# Altium schematic sheet MCU.SchDoc(MCU)
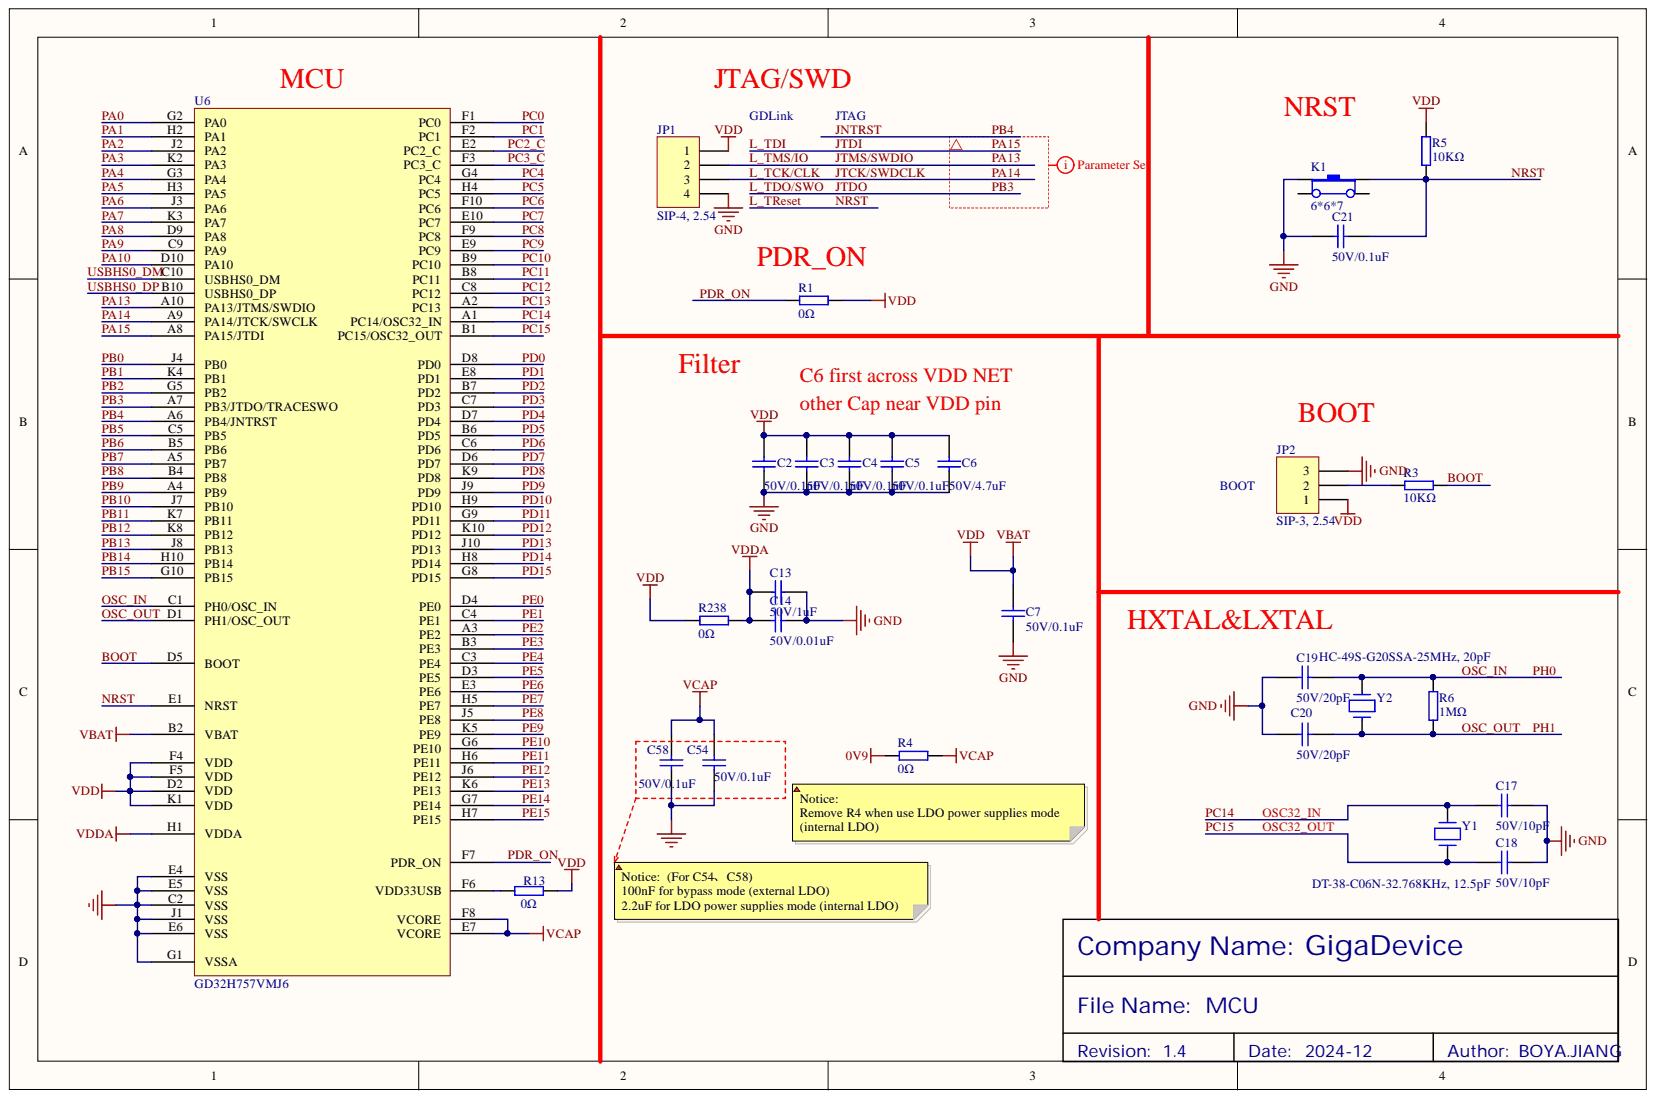
[diagram p0: MCU.SchDoc]

## Parts
C2  50V/0.1uF  [0603-C]
C3  50V/0.1uF  [0603-C]
C4  50V/0.1uF  [0603-C]
C5  50V/0.1uF  [0603-C]
C6  50V/4.7uF  [0603-C]
C7  50V/0.1uF  [0603-C]
C13  50V/1uF  [0603-C]
C14  50V/0.01uF  [0603-C]
C17  50V/10pF  [0603-C]
C18  50V/10pF  [0603-C]
C19  50V/20pF  [0603-C]
C20  50V/20pF  [0603-C]
C21  50V/0.1uF  [0603-C]
C54  50V/0.1uF  [0603-C]
C58  50V/0.1uF  [0603-C]
JP1  SIP-4, 2.54  [SIP-4]
JP2  SIP-3, 2.54  [SIP-3]
K1  6*6*7  [K-1102B]
R1  0Ω  [0603-R]
R3  10KΩ  [0603-R]
R4  0Ω  [0805-R]
R5  10KΩ  [0603-R]
R6  1MΩ  [0603-R]
R13  0Ω  [0603-R]
R238  0Ω  [0603-R]
U6  GD32H757VMJ6  [BGA100_8X8X1.1]
Y1  DT-38-C06N-32.768KHz, 12.5pF  [DT-26]
Y2  HC-49S-G20SSA-25MHz, 20pF  [HC-49S]

## Nets
0V9: R4.1
GND: C2.1 C3.1 C4.1 C5.1 C6.1 C7.2 C13.1 C14.1 C17.2 C18.1 C19.1 C20.1 C21.2 C54.2 C58.2 JP1.4 JP2.3 K1.1 U6.C2(VSS) U6.E4(VSS) U6.E5(VSS) U6.E6(VSS) U6.G1(VSSA) U6.J1(VSS)
VBAT: C2.2 C3.2 C4.2 C5.2 C6.2 C7.1 JP1.1 JP2.1 R1.1 R5.2 R13.1 R238.1 U6.B2(VBAT) U6.D2(VDD) U6.F4(VDD) U6.F5(VDD) U6.K1(VDD)
VCAP: C54.1 C58.1 R4.2 U6.E7(VCORE) U6.F8(VCORE)
VDDA: C13.2 C14.2 R238.2 U6.H1(VDDA)
BOOT: R3.1 U6.D5(BOOT)
JNTRST: U6.A6(PB4/JNTRST)
JTCK/SWDCLK: JP1.3 U6.A9(PA14/JTCK/SWCLK)
JTDI: U6.A8(PA15/JTDI)
JTDO: U6.A7(PB3/JTDO/TRACESWO)
JTMS/SWDIO: JP1.2 U6.A10(PA13/JTMS/SWDIO)
L_TReset: C21.1 K1.3 R5.1 U6.E1(NRST)
OSC_IN: C19.2 R6.2 U6.C1(PH0/OSC_IN) Y2.2
OSC_OUT: C20.2 R6.1 U6.D1(PH1/OSC_OUT) Y2.1
PA0: U6.G2(PA0)
PA1: U6.H2(PA1)
PA2: U6.J2(PA2)
PA3: U6.K2(PA3)
PA4: U6.G3(PA4)
PA5: U6.H3(PA5)
PA6: U6.J3(PA6)
PA7: U6.K3(PA7)
PA8: U6.D9(PA8)
PA9: U6.C9(PA9)
PA10: U6.D10(PA10)
PB0: U6.J4(PB0)
PB1: U6.K4(PB1)
PB2: U6.G5(PB2)
PB5: U6.C5(PB5)
PB6: U6.B5(PB6)
PB7: U6.A5(PB7)
PB8: U6.B4(PB8)
PB9: U6.A4(PB9)
PB10: U6.J7(PB10)
PB11: U6.K7(PB11)
PB12: U6.K8(PB12)
PB13: U6.J8(PB13)
PB14: U6.H10(PB14)
PB15: U6.G10(PB15)
PC0: U6.F1(PC0)
PC1: U6.F2(PC1)
PC2_C: U6.E2(PC2_C)
PC3_C: U6.F3(PC3_C)
PC4: U6.G4(PC4)
PC5: U6.H4(PC5)
PC6: U6.F10(PC6)
PC7: U6.E10(PC7)
PC8: U6.F9(PC8)
PC9: U6.E9(PC9)
PC10: U6.B9(PC10)
PC11: U6.B8(PC11)
PC12: U6.C8(PC12)
PC13: U6.A2(PC13)
PC14: C17.1 U6.A1(PC14/OSC32_IN) Y1.2
PC15: C18.2 U6.B1(PC15/OSC32_OUT) Y1.1
PD0: U6.D8(PD0)
PD1: U6.E8(PD1)
PD2: U6.B7(PD2)
PD3: U6.C7(PD3)
PD4: U6.D7(PD4)
PD5: U6.B6(PD5)
PD6: U6.C6(PD6)
PD7: U6.D6(PD7)
PD8: U6.K9(PD8)
PD9: U6.J9(PD9)
PD10: U6.H9(PD10)
PD11: U6.G9(PD11)
PD12: U6.K10(PD12)
PD13: U6.J10(PD13)
PD14: U6.H8(PD14)
PD15: U6.G8(PD15)
PDR_ON: R1.2 U6.F7(PDR_ON)
PE0: U6.D4(PE0)
PE1: U6.C4(PE1)
PE2: U6.A3(PE2)
PE3: U6.B3(PE3)
PE4: U6.C3(PE4)
PE5: U6.D3(PE5)
PE6: U6.E3(PE6)
PE7: U6.H5(PE7)
PE8: U6.J5(PE8)
PE9: U6.K5(PE9)
PE10: U6.G6(PE10)
PE11: U6.H6(PE11)
PE12: U6.J6(PE12)
PE13: U6.K6(PE13)
PE14: U6.G7(PE14)
PE15: U6.H7(PE15)
USBHS0_DM: U6.C10(USBHS0_DM)
USBHS0_DP: U6.B10(USBHS0_DP)
NetJP2_2: JP2.2 R3.2
NetR13_2: R13.2 U6.F6(VDD33USB)
N00187:

Not connected: K1 2 4

## Library data of the parts
C2-C7 C13 C14 C21 C54 C58 = 50V/0.1uF: 表贴电容; Component Kind: Standard; Library Name: KLTC-电容.SchLib
C17 C18 = 50V/10pF: 表贴电容; Component Kind: Standard; Library Name: KLTC-电容.SchLib
C19 C20 = 50V/20pF: 表贴电容; Component Kind: Standard; Library Name: KLTC-电容.SchLib
JP1 = HEADER 4: 单排插针; Component Kind: Standard; Library Name: KLTC-按键及接插件.SchLib
JP2 = HEADER 3: 单排插针; Component Kind: Standard; Library Name: KLTC-按键及接插件.SchLib
K1 = K-1102B: 普通6X6X7直插按键; Component Kind: Standard; Library Name: KLTC-按键及接插件.SchLib
R1 R3 R5 = 10KΩ: 表贴电阻; Component Kind: Standard; Library Name: KLTC-电阻.SchLib
R4 R13 R238 = 0Ω: 表贴电阻; Component Kind: Standard
  R4 R238: Library Name: GDM3203ZE-EVAL.IntLib
  R13: Library Name: KLTC-电阻.SchLib
R6 = 1MΩ: 表贴电阻; Component Kind: Standard; Library Name: KLTC-电阻.SchLib
U6 = GD32H7xxVxJ6: 表贴MCU芯片; Library Name: GD32.schlib; Component Kind: Standard
Y1 = DT-26-C06N-32.768KHz: 直插晶振; Component Kind: Standard; Library Name: KLTC-晶振.SchLib
Y2 = HC-49S-G20SSA-8MHz: 直插晶振; Component Kind: Standard; Library Name: KLTC-晶振.SchLib
